# Altium schematic sheet Analog_Frontend01.SchDoc
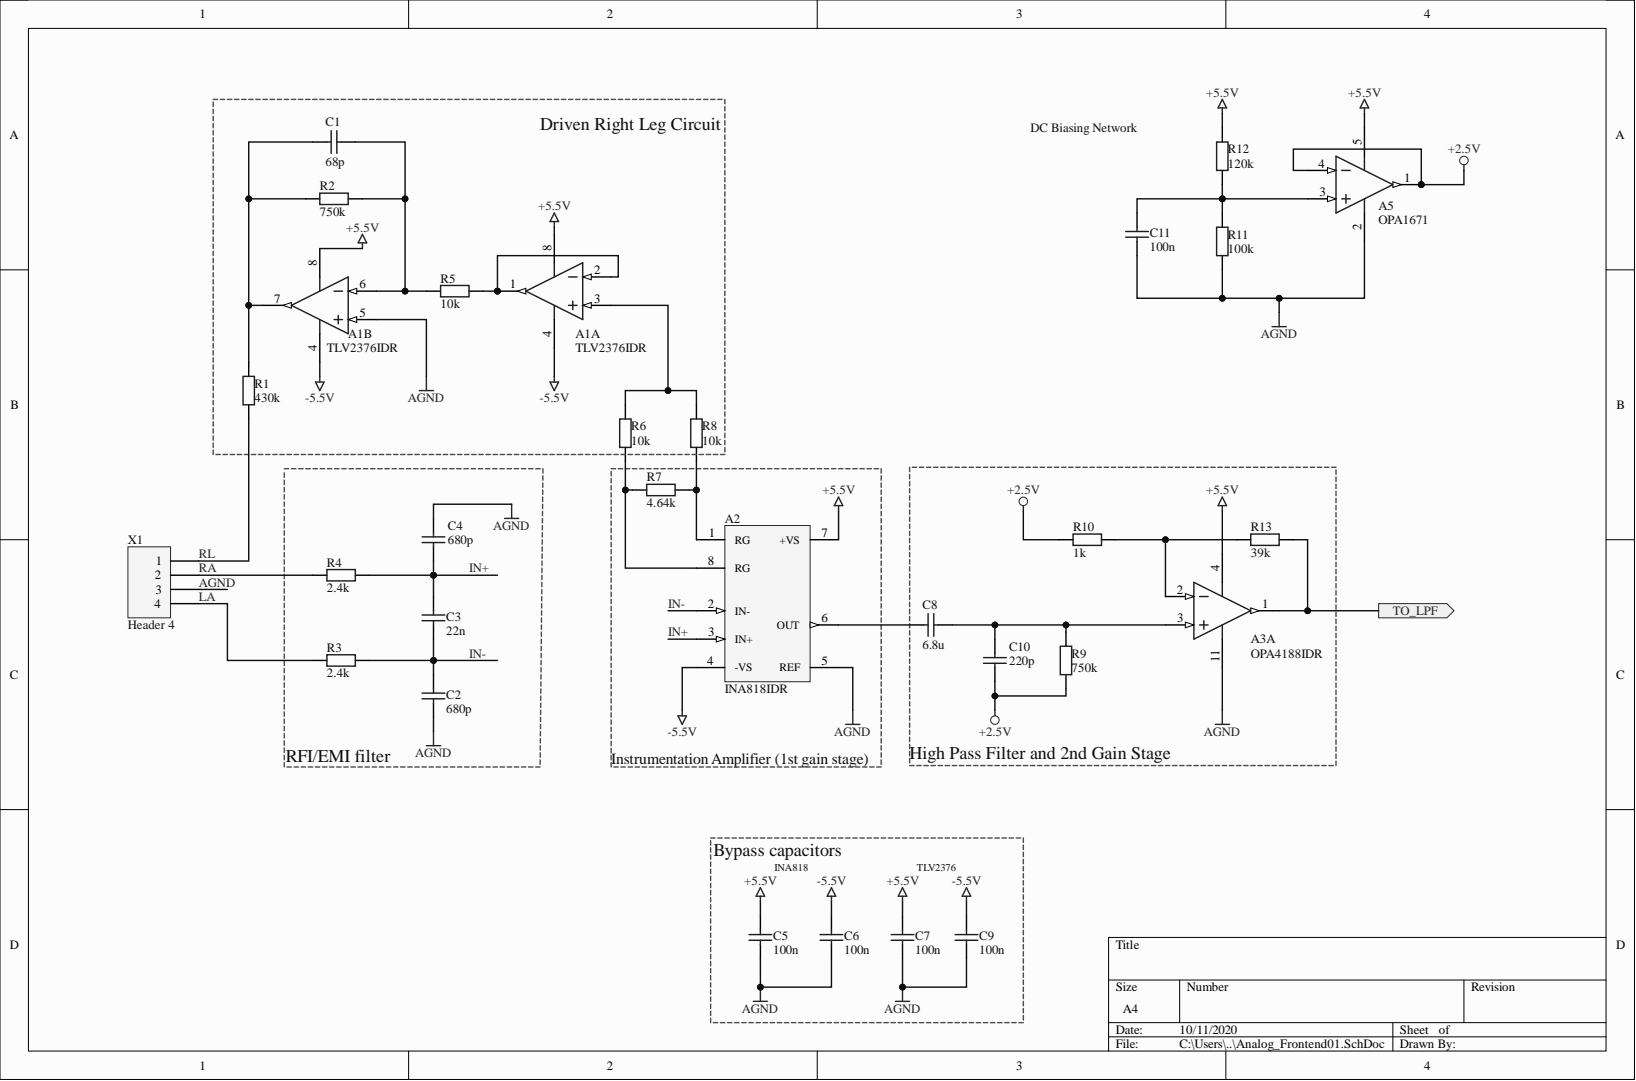
[diagram p0: Analog_Frontend01.SchDoc]

## Parts
A1  TLV2376IDR  [SOIC127P600X175-8N]  (parts A,B)
A2  INA818IDR  [SOIC127P600X175-8N]
A3  OPA4188IDR  [SOIC127P600X175-14N]  (part A)
A5  OPA1671  [SOT95P280X145-5N]
C1  68p
C2  680p  [CAPC2012X110N]
C3  22n  [CAPC3225X170N]
C4  680p  [CAPC2012X110N]
C5  100n  [CAPC1608X87N]
C6  100n  [CAPC1608X87N]
C7  100n  [CAPC1608X87N]
C8  6.8u  [CAPC2012X110N]
C9  100n  [CAPC1608X87N]
C10  220p  [CAPC1608X87N]
C11  100n  [CAPC1608X87N]
R1  430k  [RESC1608X55N]
R2  750k  [RESC1608X55N]
R3  2.4k  [RESC2012X70N]
R4  2.4k  [RESC2012X70N]
R5  10k  [RESC1608X55N]
R6  10k  [RESC1608X55N]
R7  4.64k  [RESC1608X55N]
R8  10k  [RESC1608X55N]
R9  750k  [RESC1608X55N]
R10  1k  [RESC1608X55N]
R11  100k  [RESC1608X55N]
R12  120k  [RESC1608X55N]
R13  39k  [RESC1608X55N]
X1  Header 4

## Ports
TO_LPF

## Nets
+2.5V: A5.1 A5.4 C10.1 R9.1 R10.1
+5.5V: A1.8 A2.7(+VS) A3.4 A5.5 C5.2 C7.2 R12.1
-5.5V: A1.4 A2.4(-VS) C6.2 C9.2
AGND: A1.5 A2.5(REF) A3.11 A5.2 C2.1 C4.2 C5.1 C6.1 C7.1 C9.1 C11.1 R11.2 X1.3
IN+: A2.3(IN+) C3.2 C4.1 R4.2
IN-: A2.2(IN-) C2.2 C3.1 R3.2
LA: R3.1 X1.4
RA: R4.1 X1.2
RL: R1.1 X1.1
TO_LPF: A3.1 R13.2 ; port TO_LPF
NetA1_1: A1.1 A1.2 R5.2
NetA1_3: A1.3 R6.2 R8.2
NetA1_6: A1.6 C1.1 R2.2 R5.1
NetA1_7: A1.7 C1.2 R1.2 R2.1
NetA2_1: A2.1(RG) R7.2 R8.1
NetA2_6: A2.6(OUT) C8.2
NetA2_8: A2.8(RG) R6.1 R7.1
NetA3_2: A3.2 R10.2 R13.1
NetA3_3: A3.3 C8.1 C10.2 R9.2
NetA5_3: A5.3 C11.2 R11.1 R12.2

## Library data of the parts
A1 = AD706AR: Manufacturer 1: Texas Instruments; Component Kind: Standard; Library Name: Analog Devices Operational Amplifiers.IntLib; Manufacturer Part Number 1: TLV2376IDR
A2 = INAMP: Manufacturer 1: Texas Instruments; Component Kind: Standard; Library Name: Custom_Lib.SchLib; Manufacturer Part Number 1: INA818IDR; MOUSER Part Number: 595-INA818IDR
A3 = AD824ARZ-14-3V-RL: Manufacturer 1: Texas Instruments; Manufacturer Part Number 1: OPA4188AIDR; Component Kind: Standard; Library Name: Analog Devices Operational Amplifiers.IntLib
A5 = OPA336NJ/250: Manufacturer 1: Texas Instruments; Manufacturer Part Number 1: OPA1671IDBVR; Component Kind: Standard; Library Name: TI Amplifiers and Linear Operational Amplifier Precision Amplifier Part One.IntLib
C1 = Cap: Component Kind: Standard; Library Name: Miscellaneous Devices.IntLib; Signal Integrity: Cap; Simulation: CAP
C2 C4 = Cap: Manufacturer 1: Panasonic; Manufacturer Part Number 1: ECH-U1H681GX5; Component Kind: Standard; Library Name: Miscellaneous Devices.IntLib; MOUSER Part Number: 667-ECH-U1H681GX5; Signal Integrity: Cap; Simulation: CAP
C3 = Cap: Manufacturer 1: Panasonic; Manufacturer Part Number 1: ECH-U1H223GX5; Component Kind: Standard; Library Name: Miscellaneous Devices.IntLib; MOUSER Part Number: 667-ECH-U1H223GX5; Signal Integrity: Cap; Simulation: CAP
C5-C7 C9 C11 = Cap: Manufacturer 1: Taiyo Yuden; Manufacturer Part Number 1: EMK107B7104KA-T; Component Kind: Standard; Library Name: Miscellaneous Devices.IntLib; Signal Integrity: Cap; Simulation: CAP
C8 = Cap: Manufacturer 1: TDK; Manufacturer Part Number 1: C2012X6S1C685M125AC; Component Kind: Standard; Library Name: Miscellaneous Devices.IntLib; Signal Integrity: Cap; Simulation: CAP
C10 = Cap: Manufacturer 1: Vishay/Vitramon; Manufacturer Part Number 1: VJ0603Y221KXJPW1BC; Component Kind: Standard; Library Name: Miscellaneous Devices.IntLib; Signal Integrity: Cap; Simulation: CAP
R1 = Res2: Manufacturer 1: Bourns; Manufacturer Part Number 1: CR0603-FX-4303ELF; Component Kind: Standard; Library Name: Miscellaneous Devices.IntLib; Signal Integrity: Res; Simulation: RESISTOR
R2 R9 = Res2: Manufacturer 1: Bourns; Manufacturer Part Number 1: CR0603-FX-7503ELF; Component Kind: Standard; Library Name: Miscellaneous Devices.IntLib; Signal Integrity: Res; Simulation: RESISTOR
R3 R4 = Res2: Manufacturer 1: Panasonic; Manufacturer Part Number 1: ERJ-6ENF2401V; Component Kind: Standard; Library Name: Miscellaneous Devices.IntLib; MOUSER Part Number: 667-ERJ-6ENF2401V; Signal Integrity: Res; Simulation: RESISTOR
R5 R6 R8 = Res2: Manufacturer 1: Yageo; Manufacturer Part Number 1: RC0603FR-0710KL; Component Kind: Standard; Library Name: Miscellaneous Devices.IntLib; MOUSER Part Number: 603-RC0603FR-0710KL; Signal Integrity: Res; Simulation: RESISTOR
R7 = Res2: Manufacturer 1: Bourns; Manufacturer Part Number 1: CR0603-FX-4641ELF; Component Kind: Standard; Library Name: Miscellaneous Devices.IntLib; Signal Integrity: Res; Simulation: RESISTOR
R10 = Res2: Manufacturer 1: Yageo; Manufacturer Part Number 1: RC0603FR-071KL; Component Kind: Standard; Library Name: Miscellaneous Devices.IntLib; Signal Integrity: Res; Simulation: RESISTOR
R11 = Res2: Manufacturer 1: Panasonic; Manufacturer Part Number 1: ERJ-3EKF1003V; Component Kind: Standard; Library Name: Miscellaneous Devices.IntLib; Signal Integrity: Res; Simulation: RESISTOR
R12 = Res2: Manufacturer 1: Bourns; Manufacturer Part Number 1: CR0603-FX-1203ELF; Component Kind: Standard; Library Name: Miscellaneous Devices.IntLib; Signal Integrity: Res; Simulation: RESISTOR
R13 = Res2: Manufacturer 1: Bourns; Manufacturer Part Number 1: CR0603-FX-3902ELF; Component Kind: Standard; Library Name: Miscellaneous Devices.IntLib; Signal Integrity: Res; Simulation: RESISTOR
X1 = Header 4: Component Kind: Standard; Library Name: Miscellaneous Connectors.IntLib; Signal Integrity: Connector
